# Altium schematic sheet Sheet1.SchDoc(Sheet1)
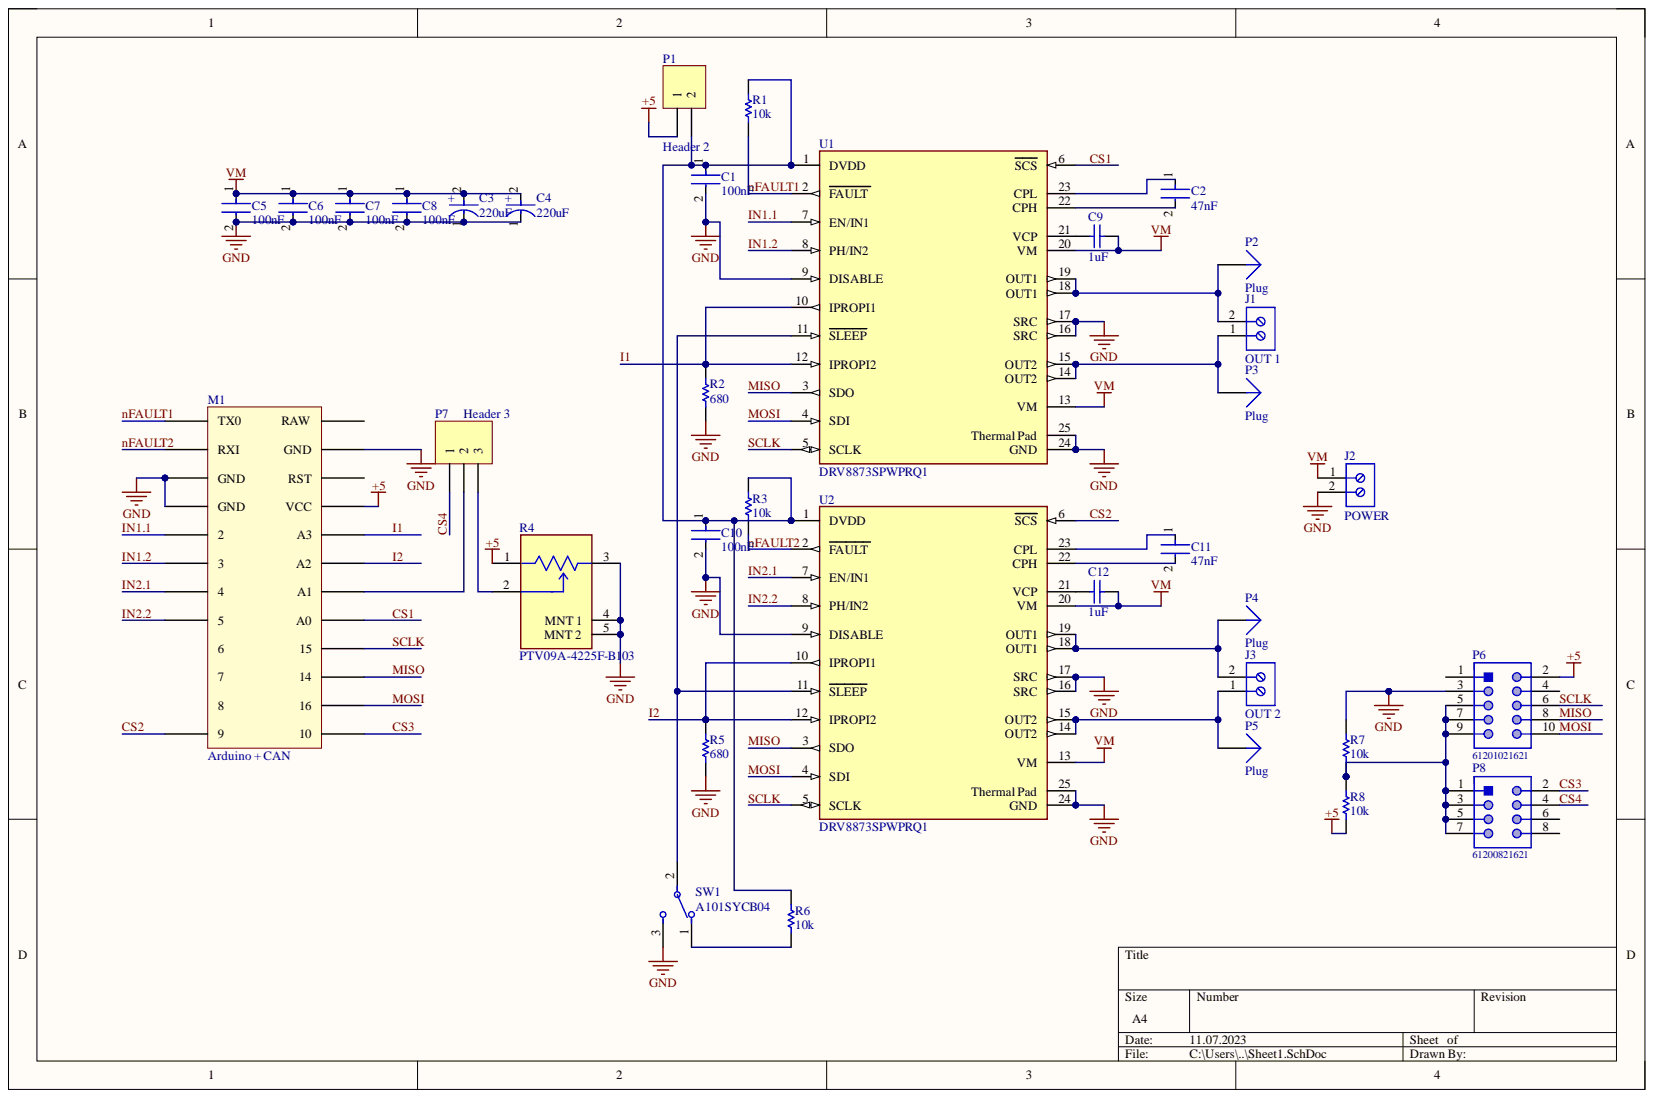
[diagram p0: Sheet1.SchDoc]

## Parts
C1  100nF  [FP-0805-L_2_01_0_2-W_1_25-IPC_B]
C2  47nF  [FP-C0805C-DN-MFG]
C3  220uF  [FP-UWT1V221MNL1GS-MFG]
C4  220uF  [FP-UWT1V221MNL1GS-MFG]
C5  100nF  [FP-0805-L_2_01_0_2-W_1_25-IPC_B]
C6  100nF  [FP-0805-L_2_01_0_2-W_1_25-IPC_B]
C7  100nF  [FP-0805-L_2_01_0_2-W_1_25-IPC_B]
C8  100nF  [FP-0805-L_2_01_0_2-W_1_25-IPC_B]
C9  1uF  [CAPC2013X145X50LL20T25]
C10  100nF  [FP-0805-L_2_01_0_2-W_1_25-IPC_B]
C11  47nF  [FP-C0805C-DN-MFG]
C12  1uF  [CAPC2013X145X50LL20T25]
J1  OUT 1  [691102710002]
J2  POWER  [691102710002]
J3  OUT 2  [691102710002]
M1  Arduino + CAN  [PCBComponent_1]
P1  Header 2  [HDR1X2]
P2  Plug  [PIN1]
P3  Plug  [PIN1]
P4  Plug  [PIN1]
P5  Plug  [PIN1]
P6  61201021621  [61201021621]
P7  Header 3  [HDR1X3]
P8  61200821621  [61200821621]
R1  10k  [RESC2013X60X35NL10T20]
R2  680  [RESC2013X60X35NL10T20]
R3  10k  [RESC2013X60X35NL10T20]
R4  PTV09A-4225F-B103  [FP-PTV09A-4-MFG]
R5  680  [RESC2013X60X35NL10T20]
R6  10k  [RESC2013X60X35NL10T20]
R7  10k  [RESC2013X60X35NL10T20]
R8  10k  [RESC2013X60X35NL10T20]
SW1  A101SYCB04  [FP-A101SYCB04-MFG]
U1  DRV8873SPWPRQ1  [FP-PWP0024J-MFG]
U2  DRV8873SPWPRQ1  [FP-PWP0024J-MFG]

## Nets
+5: M1.21(VCC) P1.1 P6.2 R4.1 R8.1
GND: C1.2 C3.1 C4.1 C5.2 C6.2 C7.2 C8.2 C10.2 J2.2 M1.3(GND) M1.4(GND) M1.23(GND) P6.3 R2.1 R4.3 R4.4(MNT_1) R4.5(MNT_2) R5.1 R7.2 SW1.3 U1.9(DISABLE) U1.16(SRC) U1.17(SRC) U1.24(GND) U1.25(Thermal_Pad) U2.9(DISABLE) U2.16(SRC) U2.17(SRC) U2.24(GND) U2.25(Thermal_Pad)
VM: C3.2 C4.2 C5.1 C6.1 C7.1 C8.1 C9.2 C12.2 J2.1 U1.13(VM) U1.20(VM) U2.13(VM) U2.20(VM)
CS1: M1.17(A0) U1.6(SCS)
CS2: M1.12 U2.6(SCS)
CS3: M1.13 P8.2
CS4: P7.1 P8.4
I1: M1.20(A3) R2.2 U1.10(IPROPI1) U1.12(IPROPI2)
I2: M1.19(A2) R5.2 U2.10(IPROPI1) U2.12(IPROPI2)
IN1.1: M1.5 U1.7(EN/IN1)
IN1.2: M1.6 U1.8(PH/IN2)
IN2.1: M1.7 U2.7(EN/IN1)
IN2.2: M1.8 U2.8(PH/IN2)
MISO: M1.15 P6.8 U1.3(SDO) U2.3(SDO)
MOSI: M1.14 P6.10 U1.4(SDI) U2.4(SDI)
nFAULT1: M1.1(TX0) R1.1 U1.2(FAULT)
nFAULT2: M1.2(RXI) R3.1 U2.2(FAULT)
SCLK: M1.16 P6.6 U1.5(SCLK) U2.5(SCLK)
NetC1_1: C1.1 C10.1 P1.2 R1.2 R3.2 R6.2 U1.1(DVDD) U2.1(DVDD)
NetC2_1: C2.1 U1.23(CPL)
NetC2_2: C2.2 U1.22(CPH)
NetC9_1: C9.1 U1.21(VCP)
NetC11_1: C11.1 U2.23(CPL)
NetC11_2: C11.2 U2.22(CPH)
NetC12_1: C12.1 U2.21(VCP)
NetJ1_1: J1.1 P3.1 U1.14(OUT2) U1.15(OUT2)
NetJ1_2: J1.2 P2.1 U1.18(OUT1) U1.19(OUT1)
NetJ3_1: J3.1 P5.1 U2.14(OUT2) U2.15(OUT2)
NetJ3_2: J3.2 P4.1 U2.18(OUT1) U2.19(OUT1)
NetM1_18: M1.18(A1) P7.2
NetP6_5: P6.5 P6.7 P6.9 P8.1 P8.3 P8.5 P8.7 R7.1 R8.2
NetP7_3: P7.3 R4.2
NetR6_1: R6.1 SW1.1
NetSW1_2: SW1.2 U1.11(SLEEP) U2.11(SLEEP)

Not connected: M1 9 10 11 22(RST) 24(RAW); P6 1 4; P8 6 8

## Library data of the parts
C1 C5-C8 C10 = CMP-2007-04174-2: General Purpose Ceramic Capacitor, 0805, 100nF, 10%, X7R, 15%, 100V; Capacitance: 100nF; Case Code (Imperial): 0805; Case Code (Metric): 2012; Case/Package: 0805; Component Kind: Standard; Construction: Flat; Contact Plating: Tin; Depth: 1.25mm; Dielectric: X7R; Dielectric Material: Ceramic; Height: 1.4mm; Lead Free: Lead Free; Length: 2.01mm; Library Name: Altium Content Vault; Max Operating Temperature: 125°C; Min Operating Temperature: -55°C; Mount: Surface Mount; Number of Pins: 2; Package Quantity: 2000; Packaging: Tape and Reel; Radiation Hardening: No; REACH SVHC: No SVHC; RoHS Compliant: Yes; Series: -; Termination: SMD/SMT; Thickness: 0.055inch; Tolerance: 10%; Voltage: 100V; Voltage Rating: 100V; Voltage Rating (DC): 100V; Width: 0.049inch
C2 C11 = CMP-2007-01098-2: CAP CER 0.047UF 50V X7R 0805; Manufacturer: KEMET; Manufacturer Part Number: C0805C473K5RACTU; Capacitance: 47,000 pF; Material: Ceramic; Temperature Coefficient: 30ppm/°C; Tolerance: 0.1; Value: 47,000 pF; Automotive: No; Category: Cap; Component Kind: Standard; ComponentLink2Description: Datasheet; ComponentLink2URL: https://content.kemet.com/datasheets/KEM_C1003_C0G_SMD.pdf; Device Class L1: Passive Components; Device Class L2: Capacitors; Device Class L3: Ceramic Capacitors; Digikey Description: CAP CER 0.047UF 50V X7R 0805; Height: 0.88mm; Ipc Land Pattern Name: CAPC200125X78; Lead Free: Yes; Library Name: Altium Content Vault; Mouser Description: Capacitor; Ceramic; Cap 0.047 uF; Tol 10%; Vol-Rtg 50 VDC; SMT; 0805; X7R; Tape&Reel; Octopart: https://octopart.com/c0805c473k5ractu-kemet-138387; Package: C0805C-DN; RoHS: TRUE; Temperature: -55°C to +125°C; Temperature Characteristic: X7R; Temperature Range High: +125°C; Temperature Range Low: -55°C; Voltage Rating: 50 V
C3 C4 = CMP-12462-000057-1: CAP ALUM 220UF 20% 35V SMD; Dielectric Material: Aluminium; Dissipation Factor: 0.14%; Lead Diameter: 1.1mm; Leakage Current: 3uA; Life (Hours): 1000Hour; Ripple Current: 190mA; Capacitance: 220uF; Case/Package: Radial; Component Kind: Standard; Construction: Cylindrical; Diameter: 8mm; Height: 10mm; Height - Seated (Max): 0.394inch; Lead Free: Lead Free; Lead Pitch: 0.327inch; Length: 10mm; Library Name: Altium Content Vault; Max Operating Temperature: 105°C; Min Operating Temperature: -55°C; Mount: Surface Mount; Number of Pins: 2; Package Quantity: 500; Packaging: Tape and Reel; Radiation Hardening: No; REACH SVHC: No SVHC; Ripple Current (AC): 190mA; RoHS Compliant: Yes; Series: WT; Termination: SMD/SMT; Tolerance: 20%; Voltage: 35V; Voltage Rating: 35V; Voltage Rating (DC): 35V
C9 C12 = CMP-1036-04746-2: Manufacturer: Kemet; Part Number: C0805C105K4PACTU; Component Kind: Standard; Library Name: Altium Content Vault
J1-J3 = CMP-1502-03258-2: NexarPartId: 8917706; Current: 15A; Number of Contacts: 2; Number of Levels: 1; Pitch: 5.0038mm; Plating: Steel; Stripping Length: -6mm; Voltage: 300V; Wire Gauge (Max): 22AWG; Wire Gauge (Min): 14AWG; Color: Blue; Component Kind: Standard; Contact Material: Brass; Contact Plating: Tin; Current Rating: 15A; Height: 13.4mm; Housing Material: Nylon; Lead Pitch: 5mm; Length: 7.5mm; Library Name: Altium Content Vault; Max Operating Temperature: 105°C; Min Operating Temperature: -40°C; Mount: Through Hole; Number of Pins: 2; Number of Positions Per Level: 2; Packaging: Box; REACH SVHC: Unknown; RoHS: Compliant; Termination: Screw; Voltage Rating: 300V; Width: 11.2mm; Wire/Cable Gauge: 22AWG
M1 = Arduino: Arduino Pro Micro + CAN Shield; Component Kind: Standard; Library Name: Arduino.SchLib
P1 = Header 2: Header, 2-Pin; Component Kind: Standard; LatestRevisionDate: 17-Jul-2002; LatestRevisionNote: Re-released for DXP Platform.; Library Name: Miscellaneous Connectors.IntLib; Signal Integrity: Connector; Publisher: Altium Limited
P6 = CMP-1502-00007-1: Male Box Header WR-BHD, THT, Vertical, pitch 2.54 mm, 10 pins; Manufacturer: Wurth Elektronik; Contact Resistance: 20mOhm; Pin Number: 10; Pitch: 2.54mm; Withstanding Voltage: 500VAC; Working Voltage: 250VAC; Component Kind: Standard; Datasheet URL: https://katalog.we-online.de/em/datasheet/6120xx21621.pdf; Gender: Male; Library Name: Altium Content Vault; Manufacturer URL: http://www.we-online.de; Mounting Technology: THT; Operating Temperature: -40to125degC; Orientation: Vertical; Package Reference: 61201021621; Packaging: Tray; Part Number: 61201021621; Published Date: August2018; Publisher: WurthElektronik
P7 = Header 3: Header, 3-Pin; Component Kind: Standard; LatestRevisionDate: 17-Jul-2002; LatestRevisionNote: Re-released for DXP Platform.; Library Name: Miscellaneous Connectors.IntLib; Signal Integrity: Connector; Publisher: Altium Limited
P8 = CMP-1502-00006-1: Male Box Header WR-BHD, THT, Vertical, pitch 2.54 mm, 8 pins; Manufacturer: Wurth Elektronik; Contact Resistance: 20mOhm; Pin Number: 8; Pitch: 2.54mm; Withstanding Voltage: 500VAC; Working Voltage: 250VAC; Component Kind: Standard; Datasheet URL: https://katalog.we-online.de/em/datasheet/6120xx21621.pdf; Gender: Male; Library Name: Altium Content Vault; Manufacturer URL: http://www.we-online.de; Mounting Technology: THT; Operating Temperature: -40to125degC; Orientation: Vertical; Package Reference: 61200821621; Packaging: Tray; Part Number: 61200821621; Published Date: August2018; Publisher: WurthElektronik
R1-R3 R5-R8 = CMP-1659-00013-4: Chip Resistor, 10 KOhm, +/- 1%, 0.125 W, -55 to 155 degC, 0805 (2012 Metric), RoHS, Tape and Reel; Manufacturer: Yageo; Voltage Rating: 150 V; Component Kind: Standard; ComponentLink1Description: Manufacturer URL; ComponentLink1URL: http://www.yageo.com/; ComponentLink2Description: Datasheet; ComponentLink2URL: http://www.yageo.com/documents/recent/PYu-RC_Group_51_RoHS_L_04.pdf; DatasheetVersion: Rev. 4, 02/2016; Height: 0.5 mm; Length: 2 mm; Library Name: Altium Content Vault; Max Operating Temperature: 155 degC; Min Operating Temperature: -55 degC; Mount: Surface Mount; Mounting Technology: Surface Mount; Package Quantity: 5000; PackageDescription: 2-Pin Surface Mounted Device, Body 2 x 1.25 mm; PackageReference: 0805; Packaging: Tape and Reel; Pins: 2; REACH SVHC: No SVHC; RoHSCompliant: true
R4 = CMP-07234-000052-1: POT 10K OHM 1/20W CARBON LINEAR; Actuator Diameter: 0.236inch; Actuator Length: 0.984inch; Hole Diameter: 9.1mm; Max Power Dissipation: 50mW; Number of Gangs: 1; Resistance: 10kR; Resistance Tolerance: 20%; Component Kind: Standard; Depth: 12.5mm; Height: 12.5mm; Length: 11.4mm; Library Name: Altium Content Vault; Max Operating Temperature: 50°C; Military Standard: Not; Min Operating Temperature: -10°C; Mount: Through Hole; Number of Turns: 1; Package Quantity: 100; Packaging: Bulk; Power Rating: 50mW; Radiation Hardening: No; REACH SVHC: No SVHC; Resistor Type: Carbon; RoHS Compliant: Yes; Series: Pro Audio PTV09; Taper: Linear; Technology: CARBON FILM; Termination: PCB; Tolerance: 20%; Type: Potentiometer
SW1 = CMP-03408-000017-1: SWITCH TOGGLE SPDT 0.4VA 20V; Actuator Color: Chrome; Actuator Length: 10.41mm; Actuator Material: Phenolic; Actuator Type: Standard Round; Contact Current Rating: 400mA; Contact Resistance: 10mR; Electrical Life: 6000Cycles; Illumination Type: Non-Illuminated; Insulation Resistance: 30MR; Number of Poles: 1; Number of Positions: 2; Power Rating: 400mW; Switch Function: On-On; Component Kind: Standard; Contact Material: Copper; Contact Plating: Gold; Depth: 6.86mm; ELV: Compliant; Flammability Rating: UL94 V-1; Lead Free: Lead Free; Library Name: Altium Content Vault; Max Operating Temperature: 85°C; Max Voltage Rating (AC): 20V; Max Voltage Rating (DC): 20V; Min Operating Temperature: -30°C; Mount: Panel; Orientation: Straight; Package Quantity: 100; Packaging: Bulk; Radiation Hardening: No; REACH SVHC: No SVHC; RoHS Compliant: Yes; Series: Gemini A; Termination: PC Pins; Throw Configuration: SPDT; Voltage Rating (AC): 20V; Voltage Rating (DC): 20V
U1 U2 = CMP-04915-000063-1: IC MTRDRV UNIPLR 0-5.5V 24HTSSOP; Interface: SPI; Logic Function: AND GATE; Output Current: 10mA; Component Kind: Standard; Library Name: Altium Content Vault; Max Operating Temperature: 125°C; Min Operating Temperature: -40°C; Mount: Surface Mount; Packaging: Cut Tape; RoHS Compliant: Yes
P2-P5 = Plug: Component Kind: Standard; Description: Plug; LatestRevisionDate: 17-Jul-2002; LatestRevisionNote: Re-released for DXP Platform.; Library Name: Miscellaneous Connectors.IntLib; Signal Integrity: Connector; Publisher: Altium Limited
